# Altium schematic sheet IF1001.SchDoc
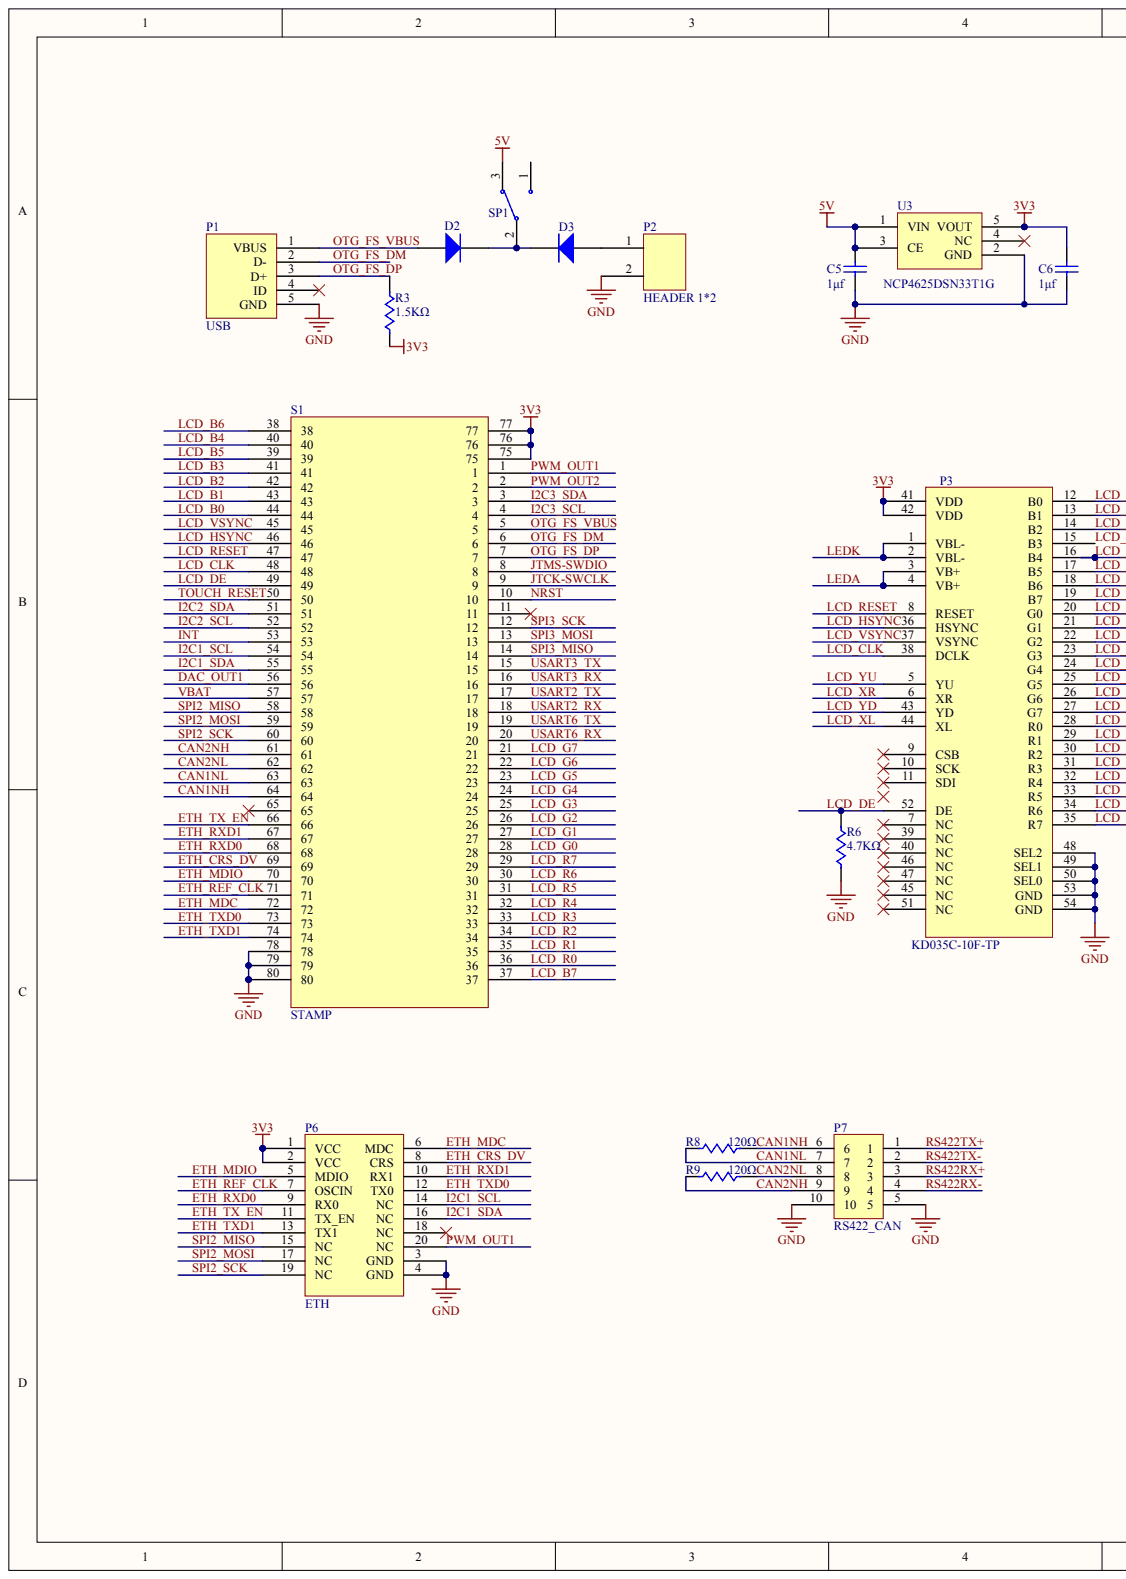
[diagram p0: IF1001.SchDoc, left]
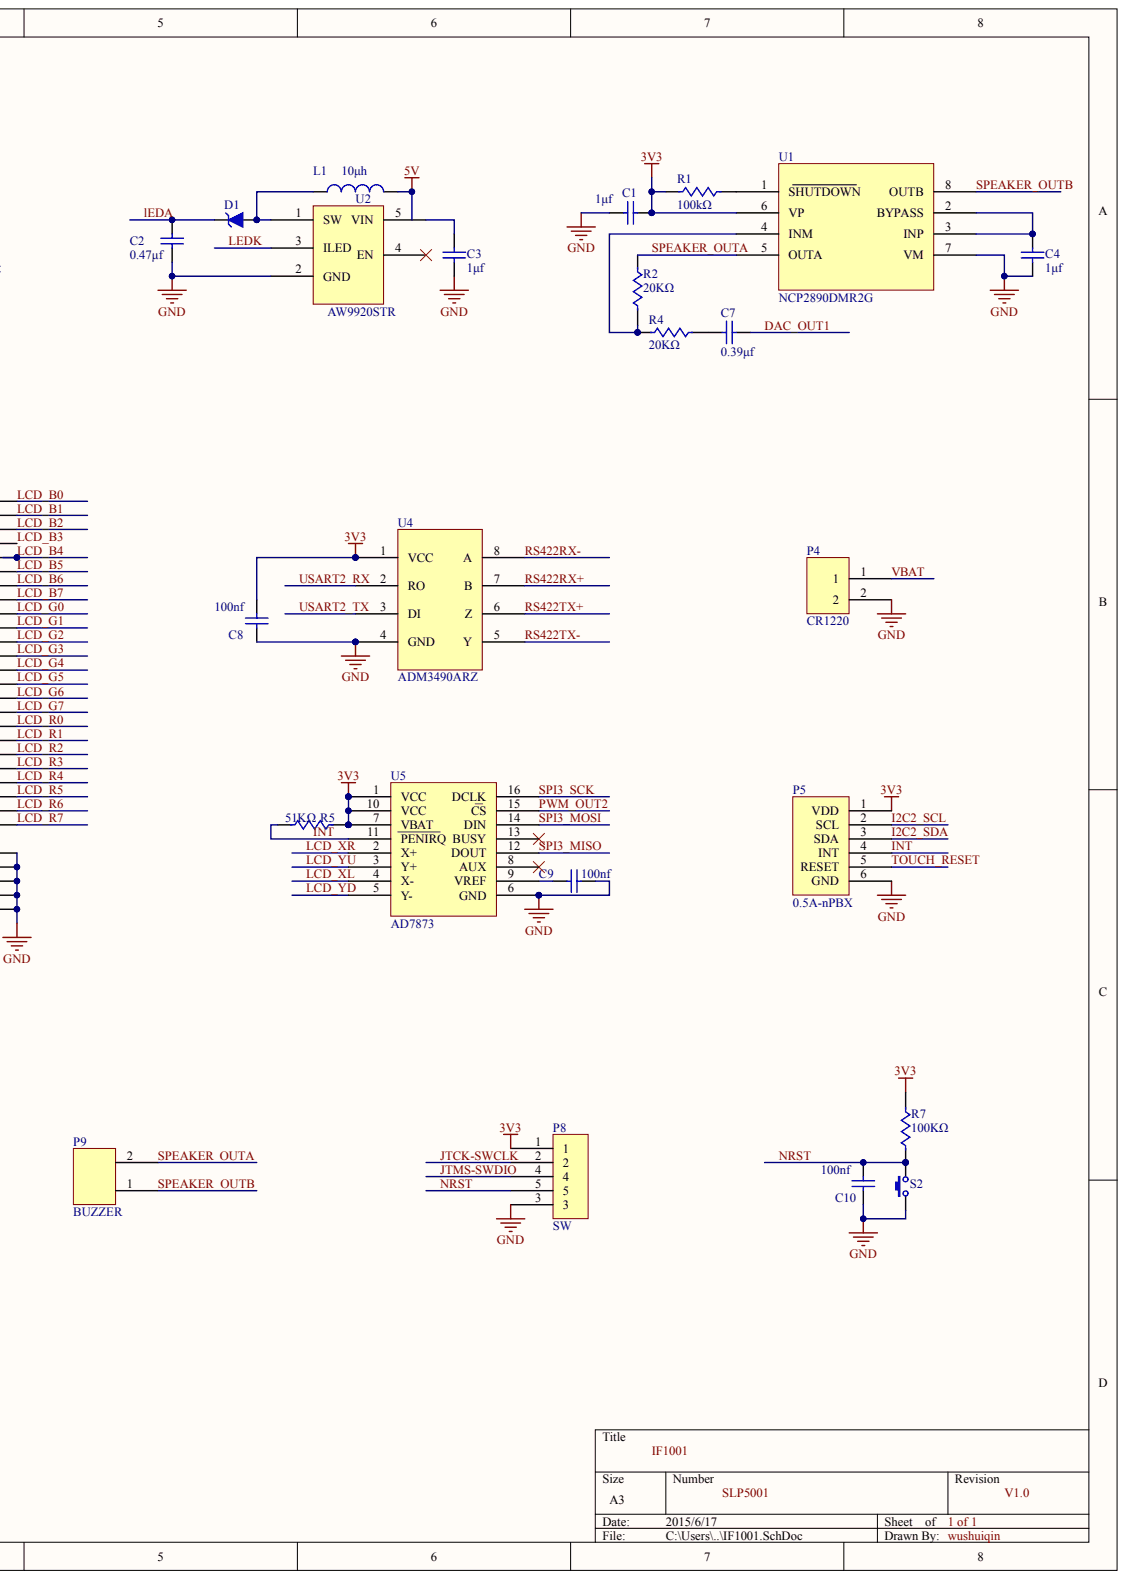
[diagram p1: IF1001.SchDoc, right]

## Parts
C1  1μf
C2  0.47μf
C3  1μf
C4  1μf
C5  1μf
C6  1μf
C7  0.39μf
C8  100nf
C9  100nf
C10  100nf
D1  MBRS140  (value not drawn)
D2  BAT60JFILM  (value not drawn)
D3  BAT60JFILM  (value not drawn)
L1  10μh
P1  USB
P2  HEADER 1*2
P3  KD035C-10F-TP
P4  CR1220
P5  0.5A-nPBX
P6  ETH
P7  RS422_CAN
P8  SW
P9  BUZZER
R1  100kΩ
R2  20KΩ
R3  1.5KΩ
R4  20KΩ
R5  51KΩ
R6  4.7KΩ
R7  100KΩ
R8  120Ω
R9  120Ω
S1  STAMP
S2  (no value)
SP1  3.00mm 3P SPDT  (value not drawn)
U1  NCP2890DMR2G
U2  AW9920STR
U3  NCP4625DSN33T1G
U4  ADM3490ARZ
U5  AD7873

## Nets
3V3: C1.2 C6.2 C8.2 P3.41(VDD) P3.42(VDD) P5.1(VDD) P6.1(VCC) P6.2(VCC) P8.1 R1.1 R3.1 R5.2 R7.2 S1.75 S1.76 S1.77 U1.6(VP) U3.5(VOUT) U4.1(VCC) U5.1(VCC) U5.7(VBAT) U5.10(VCC)
5V: C5.1 L1.2 SP1.3 U3.1(VIN) U3.3(CE)
GND: C1.1 C2.1 C3.1 C4.1 C5.2 C6.1 C8.1 C9.1 C10.1 P1.5(GND) P2.2 P3.48(SEL2) P3.49(SEL1) P3.50(SEL0) P3.53(GND) P3.54(GND) P4.2 P5.6(GND) P6.3(GND) P6.4(GND) P7.5 P7.10 P8.3 R6.1 S1.78 S1.79 S1.80 S2.1 U1.7(VM) U2.2(GND) U3.2(GND) U4.4(GND) U5.6(GND)
CAN1NH: P7.6 R8.2 S1.64
CAN1NL: P7.7 R8.1 S1.63
CAN2NH: P7.9 R9.1 S1.61
CAN2NL: P7.8 R9.2 S1.62
DAC_OUT1: C7.2 S1.56
ETH_CRS_DV: P6.8(CRS) S1.69
ETH_MDC: P6.6(MDC) S1.72
ETH_MDIO: P6.5(MDIO) S1.70
ETH_REF_CLK: P6.7(OSCIN) S1.71
ETH_RXD0: P6.9(RX0) S1.68
ETH_RXD1: P6.10(RX1) S1.67
ETH_TX_EN: P6.11(TX_EN) S1.66
ETH_TXD0: P6.12(TX0) S1.73
ETH_TXD1: P6.13(TX1) S1.74
I2C1_SCL: P6.14(NC) S1.54
I2C1_SDA: P6.16(NC) S1.55
I2C2_SCL: P5.2(SCL) S1.52
I2C2_SDA: P5.3(SDA) S1.51
I2C3_SCL: S1.4
I2C3_SDA: S1.3
INT: P5.4(INT) R5.1 S1.53 U5.11(PENIRQ)
JTCK-SWCLK: P8.2 S1.9
JTMS-SWDIO: P8.4 S1.8
LCD_B0: P3.12(B0) S1.44
LCD_B1: P3.13(B1) S1.43
LCD_B2: P3.14(B2) S1.42
LCD_B3: P3.15(B3) S1.41
LCD_B4: P3.16(B4) S1.40
LCD_B5: P3.17(B5) S1.39
LCD_B6: P3.18(B6) S1.38
LCD_B7: P3.19(B7) S1.37
LCD_CLK: P3.38(DCLK) S1.48
LCD_DE: P3.52(DE) R6.2 S1.49
LCD_G0: P3.20(G0) S1.28
LCD_G1: P3.21(G1) S1.27
LCD_G2: P3.22(G2) S1.26
LCD_G3: P3.23(G3) S1.25
LCD_G4: P3.24(G4) S1.24
LCD_G5: P3.25(G5) S1.23
LCD_G6: P3.26(G6) S1.22
LCD_G7: P3.27(G7) S1.21
LCD_HSYNC: P3.36(HSYNC) S1.46
LCD_R0: P3.28(R0) S1.36
LCD_R1: P3.29(R1) S1.35
LCD_R2: P3.30(R2) S1.34
LCD_R3: P3.31(R3) S1.33
LCD_R4: P3.32(R4) S1.32
LCD_R5: P3.33(R5) S1.31
LCD_R6: P3.34(R6) S1.30
LCD_R7: P3.35(R7) S1.29
LCD_RESET: P3.8(RESET) S1.47
LCD_VSYNC: P3.37(VSYNC) S1.45
LCD_XL: P3.44(XL) U5.4(X-)
LCD_XR: P3.6(XR) U5.2(X+)
LCD_YD: P3.43(YD) U5.5(Y-)
LCD_YU: P3.5(YU) U5.3(Y+)
LEDA: C2.2 D1.2 P3.3(VB+) P3.4(VB+)
LEDK: P3.1(VBL-) P3.2(VBL-) U2.3(ILED)
NRST: C10.2 P8.5 R7.1 S1.10 S2.2
OTG_FS_DM: P1.2(D-) S1.6
OTG_FS_DP: P1.3(D+) R3.2 S1.7
OTG_FS_VBUS: D2.1 P1.1(VBUS) S1.5
PWM_OUT1: P6.20(NC) S1.1
PWM_OUT2: S1.2 U5.15(CS)
RS422RX+: P7.3 U4.7(B)
RS422RX-: P7.4 U4.8(A)
RS422TX+: P7.1 U4.6(Z)
RS422TX-: P7.2 U4.5(Y)
SPEAKER_OUTA: P9.2 R2.1 U1.5(OUTA)
SPEAKER_OUTB: P9.1 U1.8(OUTB)
SPI2_MISO: P6.15(NC) S1.58
SPI2_MOSI: P6.17(NC) S1.59
SPI2_SCK: P6.19(NC) S1.60
SPI3_MISO: S1.14 U5.12(DOUT)
SPI3_MOSI: S1.13 U5.14(DIN)
SPI3_SCK: S1.12 U5.16(DCLK)
TOUCH_RESET: P5.5(RESET) S1.50
USART2_RX: S1.18 U4.2(RO)
USART2_TX: S1.17 U4.3(DI)
USART3_RX: S1.16
USART3_TX: S1.15
USART6_RX: S1.20
USART6_TX: S1.19
VBAT: P4.1 S1.57
NetC3_2: C3.2 U2.5(VIN)
NetC4_2: C4.2 U1.2(BYPASS) U1.3(INP)
NetC7_1: C7.1 R4.2
NetC9_2: C9.2 U5.9(VREF)
NetD1_1: D1.1 L1.1 U2.1(SW)
NetD2_2: D2.2 D3.2 SP1.2
NetD3_1: D3.1 P2.1
NetR1_2: R1.2 U1.1(SHUTDOWN)
NetR2_2: R2.2 R4.1 U1.4(INM)
1 OF 1:
IF1001:
SLP5001:
V1.0:
WUSHUIQIN:

Not connected: P1 4(ID); P3 7(NC) 9(CSB) 10(SCK) 11(SDI) 39(NC) 40(NC) 45(NC) 46(NC) 47(NC) 51(NC); P6 18(NC); S1 11 65; SP1 1; U2 4(EN); U3 4(NC); U5 8(AUX) 13(BUSY)

## Library data of the parts
C1 C3-C6 = (no symbol name): Manufacturer: TDK; Model: C1608X7R1C105K080AC; Value: 1μf
C2 = (no symbol name): Manufacturer: TDK; Model: C1608X7R1H474K080AC; Value: 0.47μf
C7 = (no symbol name): Manufacturer: kemet; Model: C0603C394K8PACTU; Value: 0.39μf
C8-C10 = (no symbol name): Manufacturer: TDK; Model: C1608X7R1H104K080AA; Value: 100nf
D1 = (no symbol name): Manufacturer: ON; Value: 0.6V(Vf) 1A 40V; Model: MBRS140
D2 D3 = (no symbol name): Manufacturer: ST; Value: 10V 3A; Model: BAT60JFILM
L1 = (no symbol name): Manufacturer: KEMET; Model: L0603C100KSMST; Value: 10μh
P1 = (no symbol name): Manufacturer: JAE; Model: UX60SC-MB-5ST(80); Value: Mini-B USB
P2 P9 = (no symbol name): Value: 2.54mm 2P Signal Straight; Manufacturer: *; Model: *
P3 = (no symbol name): Manufacturer: molex; Value: 54P 0.5mm; Model: 51296-5494
P4 = (no symbol name): Model: BS-1220-2; Value: 1220 3V
P5 = (no symbol name): Manufacturer: 深圳市芯鸿诺电子商行; Value: 6P 1mm; Model: 0.5A-nPBX
P6 = (no symbol name): Value: 2.54mm 9P  Signal Straight; Manufacturer: *; Model: *
P7 = (no symbol name): Value: 2.54 10P Straight
P8 = (no symbol name): Value: 2.54mm 5P Signal Straight; Manufacturer: *; Model: *
R1 R7 = (no symbol name): Manufacturer: VISHAY; Model: CRCW0603100KFKEA
  R1: Value: 100kΩ
  R7: Value: 100KΩ
R2 R4 = (no symbol name): Manufacturer: VISHAY; Model: CRCW060320K0FKEA; Value: 20KΩ
R3 = (no symbol name): Manufacturer: VISHAY; Model: CRCW06031K50FKEA; Value: 1.5KΩ
R5 = (no symbol name): Manufacturer: VISHAY; Model: CRCW060351K0JNEB; Value: 51KΩ
R6 = (no symbol name): Manufacturer: Vishay; Model: CRCW06034K70FKEA; Value: 4.7KΩ
R8 R9 = (no symbol name): Manufacturer: VISHAY; Model: CRCW0402120RFKED; Value: 120Ω
S1 = (no symbol name): Model: 非器件; Value: 80P 2mm
S2 = (no symbol name): Value: 6*3 2P
SP1 = (no symbol name): Manufacturer: DELINGELEC; Model: SS-12F17; Value: 3.00mm 3P SPDT
U1 = (no symbol name): Manufacturer: ON; Value: 1.08W,3/5V; Model: NCP2890DMR2G
U2 = (no symbol name): Manufacturer: AWINIC; Value: SOT-23-5L; Model: AW9920STR
U3 = (no symbol name): Manufacturer: ON; Value: 3.3V/SOT-23-5; Model: NCP4625DSN33T1G
U4 = (no symbol name): Manufacturer: ANALOG DEVICES; Value: 3.3V RS-485/RS-422; Model: ADM3490ARZ
U5 = (no symbol name): Manufacturer: AD; Value: TSSOP; Model: AD7873ARUZ
